# Altium schematic sheet H0ARAx_Frontend.SchDoc(H0ARAx_Frontend)
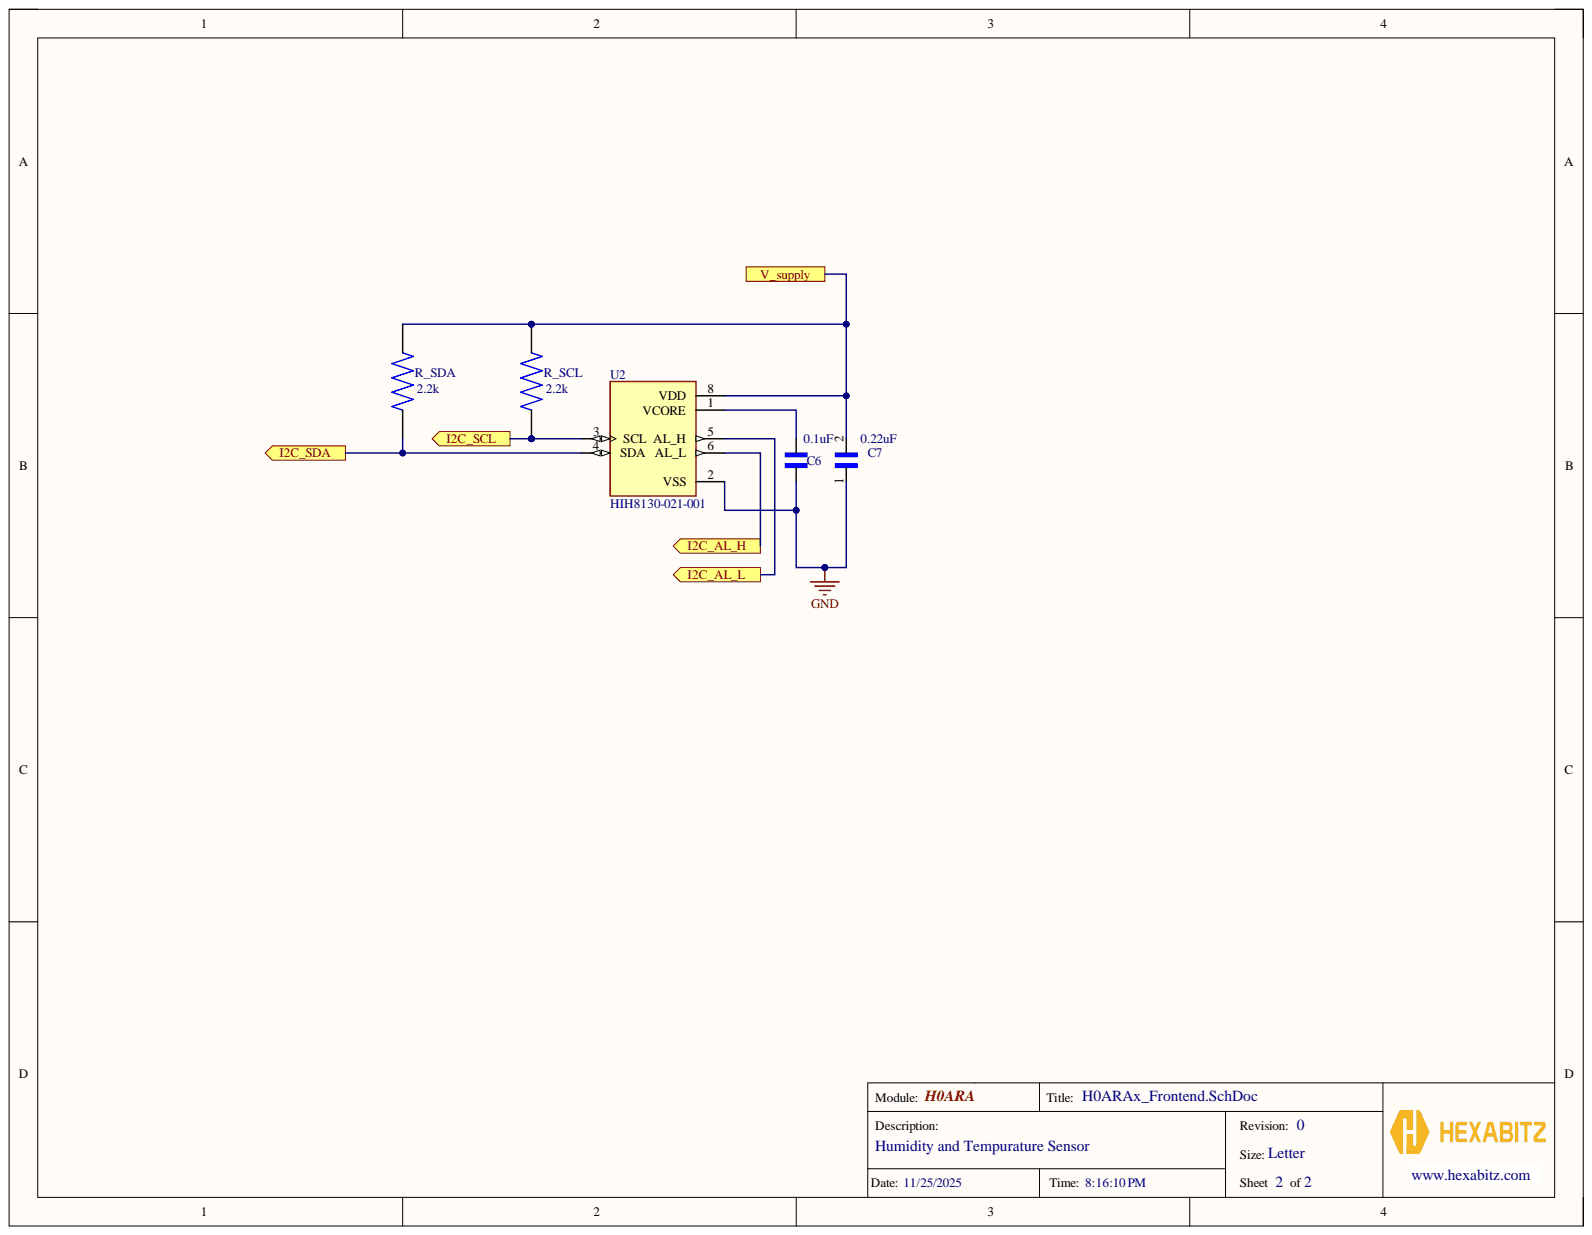
[diagram p0: H0ARAx_Frontend.SchDoc]

## Parts
C6  0.1uF  [CAPC0603X33N]
C7  0.22uF  [CAPC0603X33N]
R_SCL  2.2k  [RESC1608X55N]
R_SDA  2.2k  [RESC1608X55N]
U2  HIH8130-021-001  [SOIC127P600X206-8N]

## Ports
I2C_AL_H, I2C_AL_L, I2C_SCL, I2C_SDA, V_supply

## Nets
GND: C6.1 C7.1 U2.2(VSS)
I2C_AL_H: U2.6(AL_L) ; port I2C_AL_H
I2C_AL_L: U2.5(AL_H) ; port I2C_AL_L
I2C_SCL: R_SCL.1 U2.3(SCL) ; port I2C_SCL
I2C_SDA: R_SDA.1 U2.4(SDA) ; port I2C_SDA
V_supply: C7.2 R_SCL.2 R_SDA.2 U2.8(VDD) ; port V_supply
NetC6_2: C6.2 U2.1(VCORE)

## Library data of the parts
C6 = GRM033C71C104KE14D: 0.1 ÂµF Â±10% 16V Ceramic Capacitor X7S 0201 (0603 Metric); MF: Murata Electronics; Check_prices: https://www.snapeda.com/parts/GRM033C71C104KE14D/Murata+Electronics+North+America/view-part/?ref=eda; Component Kind: Standard; Library Name: GRM033C71C104KE14D.IntLib; MP: GRM033C71C104KE14D; Package: 0603 Murata; SnapEDA_Link: https://www.snapeda.com/parts/GRM033C71C104KE14D/Murata+Electronics+North+America/view-part/?ref=snap
C7 = GRM188R71C224KA01D: SMD Capacitor X7R_EIA_ with Capacitance: 0.22uF Tol. 10%. Rated Voltage: 16Vdc; MF: Murata; Availability: Unavailable; Component Kind: Standard; Library Name: SnapEDAsch.SchLib; MP: GRM188R71C224KA01D; Package: 1608 Murata; Price: None; SnapEDA_Link: https://www.snapeda.com/parts/GRM188R71C224KA01D/Murata%20Electronics%20North%20America/view-part/1758738/?ref=snap
R_SCL R_SDA = CRGCQ0603J2K2: Fixed Resistor CRGCQ 0603 2K2 5%; MF: TE Connectivity; Check_prices: https://www.snapeda.com/parts/CRGCQ0603J2K2/TE+Connectivity/view-part/?ref=eda; Component Kind: Standard; Library Name: CRGCQ0603J2K2.SchLib; MP: CRGCQ0603J2K2; Package: 1608 Rohm Semiconductor; SnapEDA_Link: https://www.snapeda.com/parts/CRGCQ0603J2K2/TE+Connectivity/view-part/?ref=snap
U2 = HIH8130-021-001: MF: {'manufacturer': u'Honeywell Sensing and Productivity Solutions'}; Availability: Unavailable; Component Kind: Standard; ComponentLink1Description: Supplier link; ComponentLink1URL: https://www.digikey.com/en/products/detail/honeywell-sensing-and-productivity-solutions/HIH8130-021-001/4440796; Library Name: HIH8130-021-001.IntLib; MP: HIH8130-021-001; Package: None; Price: None
